# Altium schematic sheet eFuse.SchDoc(eFuse)
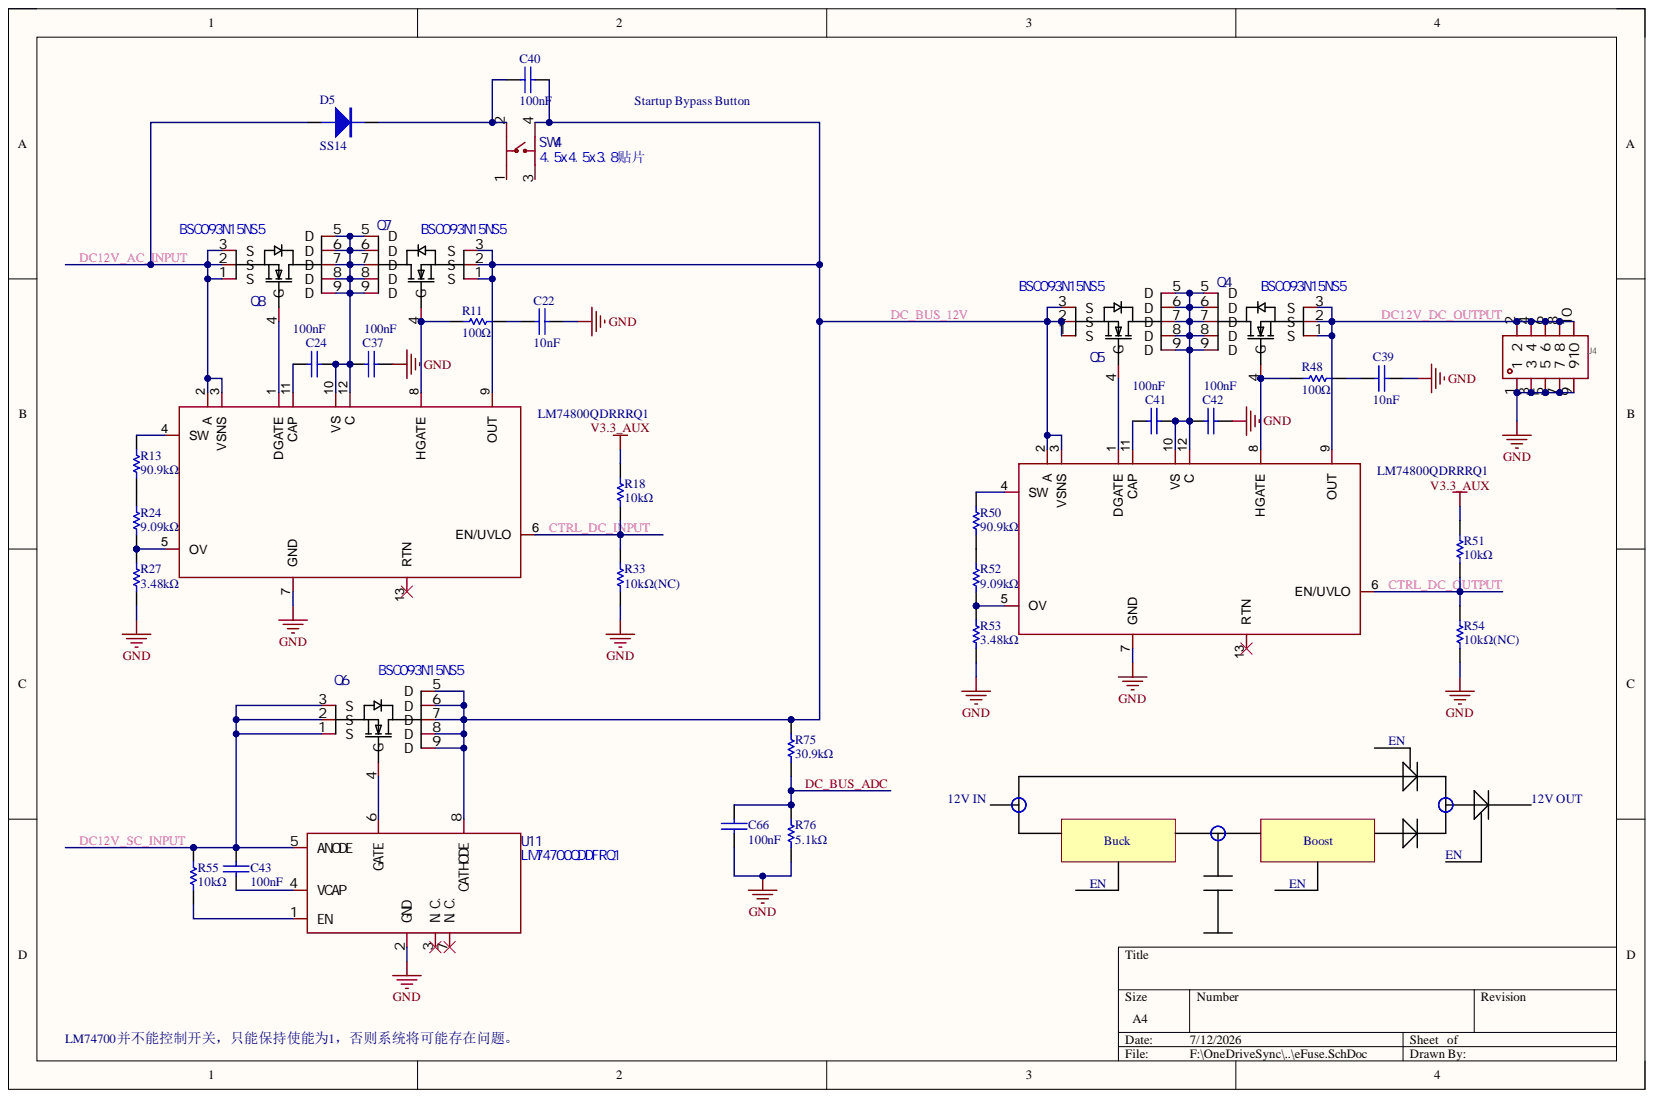
[diagram p0: eFuse.SchDoc]

## Parts
C22  10nF  [0402C]
C24  100nF  [0402C]
C37  100nF  [0402C]
C39  10nF  [0402C]
C40  100nF  [0402C]
C41  100nF  [0402C]
C42  100nF  [0402C]
C43  100nF  [0603C]
C66  100nF  [0402C]
D5  SS14  [SOD-123]
J4  PZ254-2-05-S  [HDR-SMD_10P-P2.54-V-M-R2-C5-LS7.5]  (value not drawn)
Q4  BSC093N15NS5  [PG-TDSON-8_L5.0-W6.0-P1.27-LS6.2-BL-EP]
Q5  BSC093N15NS5  [PG-TDSON-8_L5.0-W6.0-P1.27-LS6.2-BL-EP]
Q6  BSC093N15NS5  [PG-TDSON-8_L5.0-W6.0-P1.27-LS6.2-BL-EP]
Q7  BSC093N15NS5  [PG-TDSON-8_L5.0-W6.0-P1.27-LS6.2-BL-EP]
Q8  BSC093N15NS5  [PG-TDSON-8_L5.0-W6.0-P1.27-LS6.2-BL-EP]
R11  100Ω  [0402R]
R13  90.9kΩ  [0402R]
R18  10kΩ  [0402R]
R24  9.09kΩ  [0402R]
R27  3.48kΩ  [0402R]
R33  10kΩ(NC)  [0402R]
R48  100Ω  [0402R]
R50  90.9kΩ  [0402R]
R51  10kΩ  [0402R]
R52  9.09kΩ  [0402R]
R53  3.48kΩ  [0402R]
R54  10kΩ(NC)  [0402R]
R55  10kΩ  [0402R]
R75  30.9kΩ  [0402R]
R76  5.1kΩ  [0402R]
SW4  4.5x4.5x3.8贴片  [SW-SMD_4P-L4.5-W4.5-P3.00-LS6.8]
U4  LM74800QDRRRQ1  [WSON-12_L3.0-W3.0-P0.50-TL-EP-2]
U10  LM74800QDRRRQ1  [WSON-12_L3.0-W3.0-P0.50-TL-EP-2]
U11  LM74700QDDFRQ1  [TSOT-23-8_L2.9-W1.6-P0.65-LS2.8-BL]

## Nets
GND: C22.2 C37.2 C39.2 C42.2 C66.1 J4.1 J4.3 J4.5 J4.7 J4.9 R27.1 R33.1 R53.1 R54.1 R76.1 U4.7(GND) U10.7(GND) U11.2(GND)
V3.3_AUX: R18.2 R51.2
CTRL_DC_INPUT: R18.1 R33.2 U4.6(EN/UVLO)
CTRL_DC_OUTPUT: R51.1 R54.2 U10.6(EN/UVLO)
DC12V_AC_INPUT: D5.A Q8.1(S) Q8.2(S) Q8.3(S) U4.2(A) U4.3(VSNS)
DC12V_DC_OUTPUT: J4.2 J4.4 J4.6 J4.8 J4.10 Q4.1(S) Q4.2(S) Q4.3(S) U10.9(OUT)
DC12V_SC_INPUT: C43.2 Q6.1(S) Q6.2(S) Q6.3(S) R55.2 U11.5(ANODE)
DC_BUS_12V: C40.1 Q5.1(S) Q5.2(S) Q5.3(S) Q6.5(D) Q6.6(D) Q6.7(D) Q6.8(D) Q6.9(D) Q7.1(S) Q7.2(S) Q7.3(S) R75.2 SW4.4 U4.9(OUT) U10.2(A) U10.3(VSNS) U11.8(CATHODE)
DC_BUS_ADC: C66.2 R75.1 R76.2
NetC22_1: C22.1 R11.2
NetC24_1: C24.1 U4.11(CAP)
NetC24_2: C24.2 C37.1 Q7.5(D) Q7.6(D) Q7.7(D) Q7.8(D) Q7.9(D) Q8.5(D) Q8.6(D) Q8.7(D) Q8.8(D) Q8.9(D) U4.10(VS) U4.12(C)
NetC39_1: C39.1 R48.2
NetC40_2: C40.2 D5.K SW4.2
NetC41_1: C41.1 U10.11(CAP)
NetC41_2: C41.2 C42.1 Q4.5(D) Q4.6(D) Q4.7(D) Q4.8(D) Q4.9(D) Q5.5(D) Q5.6(D) Q5.7(D) Q5.8(D) Q5.9(D) U10.10(VS) U10.12(C)
NetC43_1: C43.1 U11.4(VCAP)
NetQ4_4: Q4.4(G) R48.1 U10.8(HGATE)
NetQ5_4: Q5.4(G) U10.1(DGATE)
NetQ6_4: Q6.4(G) U11.6(GATE)
NetQ7_4: Q7.4(G) R11.1 U4.8(HGATE)
NetQ8_4: Q8.4(G) U4.1(DGATE)
NetR13_1: R13.1 R24.2
NetR13_2: R13.2 U4.4(SW)
NetR24_1: R24.1 R27.2 U4.5(OV)
NetR50_1: R50.1 R52.2
NetR50_2: R50.2 U10.4(SW)
NetR52_1: R52.1 R53.2 U10.5(OV)
NetR55_1: R55.1 U11.1(EN)

Not connected: SW4 1 3; U4 13(RTN); U10 13(RTN); U11 3(N.C.) 7(N.C.)

## Library data of the parts
C22 C24 C37 C39-C43 C66 = CAP: 无极性电容; Component Kind: Standard; Library Name: 电容.IntLib
D5 = DIODE: 普通二极管; Component Kind: Standard; Library Name: 二极管.IntLib
J4 = PZ254-2-05-S: HDR-2.54-2*5P; Manufacturer: HCTL(华灿天禄); Add into BOM: yes; Circluar Pins/Square Pins: 方针; Current Rating: 3A; Pin Structure: 2x5P; Pitch: 2.54mm; Voltage Rating (Max): 250V; Color: 黑色; Component Kind: Standard; Convert to PCB: yes; Datasheet: https://item.szlcsc.com/datasheet/PZ254-2-05-S/3857820.html; Device: PZ254-2-05-S; Insulation Height: 2.5mm; JLCPCB Part Class: Extended Part; LCSC Part Name: 黑色 2x5P 间距:2.54mm 方针 立贴; Length of End Connection Pin: -; Length of Mating Pin: 6mm; Manufacturer Part: PZ254-2-05-S; Mounting Type: 立贴; Number of Pins: 10P; Number of Rows: 双排; Operating Temperature: -40℃~+105℃; PCB3D: HDR-SMD_10P-P2.54-V-M-R2-C5-LS7.5; Row Spacing: 2.54mm; Supplier: LCSC; Supplier Footprint: SMD,P=2.54mm; Supplier Part: C3294463; Symbol: PZ254-2-05-S; Library Name: GMP_PB_Core_Lib.SchLib
Q4-Q8 = BSC093N15NS5: MOSFET Infineon 150V, 87A, 9.3mΩ@10V, 44A; Manufacturer: Infineon(英飞凌); Add into BOM: yes; Gate Charge(Qg): 40.7nC@10V; Gate Threshold Voltage (Vgs(th)): 4.6V; Input Capacitance(Ciss): 3.23nF@75V; Number: 1个N沟道; Pd - Power Dissipation: 139W; Reverse Transfer Capacitance (Crss@Vds): 26pF@75V; Component Kind: Standard; Convert to PCB: yes; Current - Continuous Drain(Id): 87A; Datasheet: https://item.szlcsc.com/datasheet/BSC093N15NS5/456361.html; Device: BSC093N15NS5; Drain to Source Voltage: 150V; JLCPCB Part Class: 扩展库; LCSC Part Name: 1个N沟道 耐压:150V 电流:87A; Manufacturer Part: BSC093N15NS5; Operating Temperature: -55℃~+150℃; PCB3D: PG-TDSON-8_L5.0-W6.0-H1.0-P1.27; RDS(on): 9.3mΩ@10V,44A; Supplier: LCSC; Supplier Footprint: TDSON-8(5x6); Supplier Part: C454270; Symbol: BSC093N15NS5; Library Name: GMP_PB_Core_Lib.SchLib
R11 R13 R18 R24 R27 R33 R48 R50-R55 R75 R76 = RES: Component Kind: Standard; Library Name: 电阻.IntLib
SW4 = 4.5x4.5x3.8贴片: Manufacturer: BOOMELE(博穆精密); Actuator Style: 圆形按钮; Actuator/Cap Color: 黑色; Add into BOM: yes; Circuit: 单刀单掷; Insulation Resistance: 100MΩ; Mechanical Life: 10万次; Operating Force: 260gf; Pin Style: SMD接片; Strike Gundam: 不带; Switch Height: 3.8mm; Switch Length: 4.5mm; Voltage Rating (DC): 12V; Component Kind: Standard; Convert to PCB: yes; Datasheet: https://atta.szlcsc.com/upload/public/pdf/source/20200916/C174049_C7EFE601E72DB861B2B81D6E1A27ADFA.pdf; Device: 4.5x4.5x3.8贴片; JLCPCB Part Class: 扩展库; LCSC Part Name: 4.5*4.5*3.8mm 立贴 轻触开关; Manufacturer Part: 4.5x4.5x3.8贴片; Mounting Style: 立贴; Operating Temperature: -20℃~+80℃; PCB3D: SW-SMD_4P-L4.5-W4.5-H6.5-P3.00-LS6.8; Supplier: LCSC; Supplier Footprint: SMD; Supplier Part: C2897501; Switch Width: 4.5mm; Symbol: 4.5X4.5X3.8贴片
U4 U10 = LM74800QDRRRQ1: Ideal Diode; Component Kind: Standard; Symbol: LM74800QDRRRQ1; Library Name: GMP_PB_Core_Lib.SchLib
U11 = LM74700QDDFRQ1: Ideal Diode Driver; Manufacturer: TI(德州仪器); Add into BOM: yes; Input Voltage: 3.2V~65V; Output Current: 5A; Component Kind: Standard; Convert to PCB: yes; Datasheet: https://www.ti.com/cn/lit/gpn/lm74700-q1; Device: LM74700QDDFRQ1; JLCPCB Part Class: 扩展库; LCSC Part Name: LM74700QDDFRQ1; Manufacturer Part: LM74700QDDFRQ1; Operating Temperature	-: -40℃~+125℃; PCB3D: TSOT-23-8_L2.9-W1.6-H1.1-LS2.8-P0.65; Supplier: LCSC; Supplier Footprint: TSOT-23-8; Supplier Part: C3236229; Symbol: LM74700QDDFRQ1; Library Name: GMP_PB_Core_Lib.SchLib
